# Altium schematic sheet HighPassFilter.SchDoc(HighPassFilter)
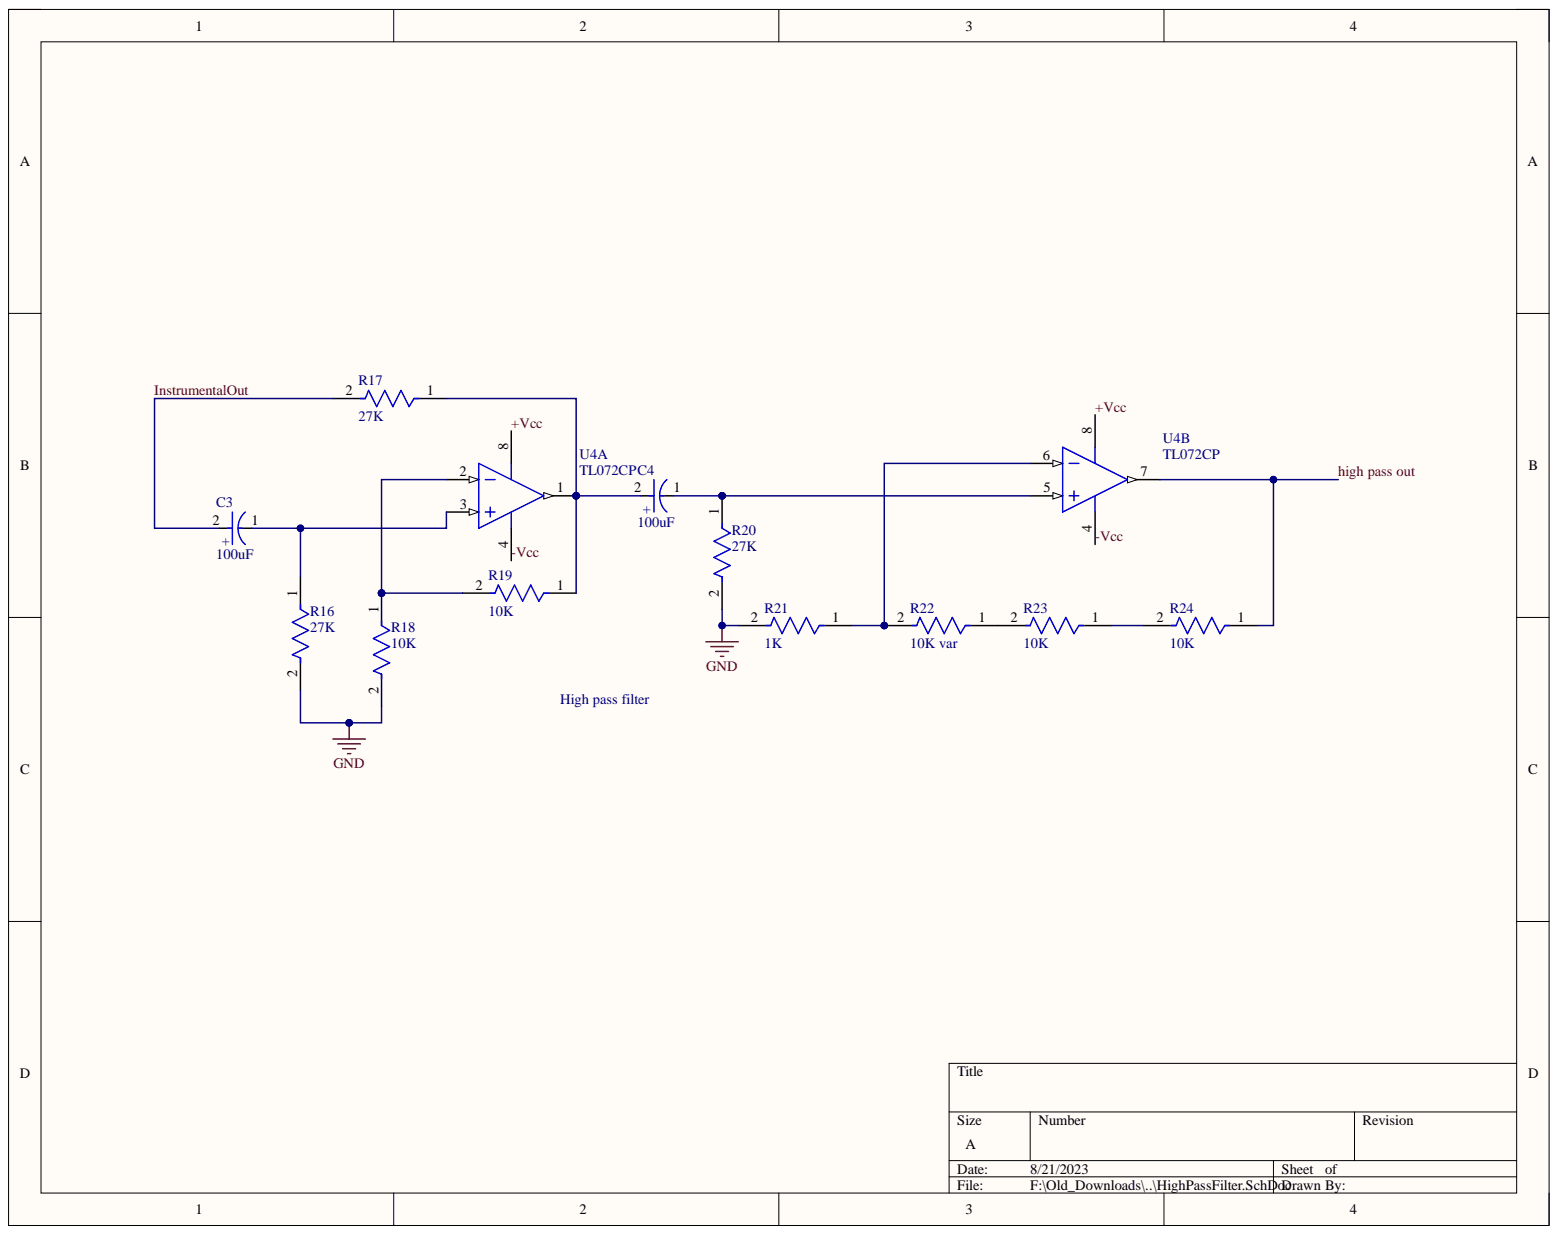
[diagram p0: HighPassFilter.SchDoc]

## Parts
C3  100uF  [FP-RAD-TH-D_6_3_0_5-L_11-MFG]
C4  100uF  [FP-RAD-TH-D_6_3_0_5-L_11-MFG]
R16  27K  [FP-CFR-25-MFG]
R17  27K  [FP-CFR-25-MFG]
R18  10K  [FP-CFR-25-MFG]
R19  10K  [FP-CFR-25-MFG]
R20  27K  [FP-CFR-25-MFG]
R21  1K  [FP-CFR-25-MFG]
R22  10K var  [FP-CFR-25-MFG]
R23  10K  [FP-CFR-25-MFG]
R24  10K  [FP-CFR-25-MFG]
U4  TL072CP  [P0008A]  (parts A,B)

## Nets
GND: R16.2 R18.2 R20.2 R21.2
+Vcc: U4.8
-Vcc: U4.4
high pass out: R24.1 U4.7
InstrumentalOut: C3.2 R17.2
NetC3_1: C3.1 R16.1 U4.3
NetC4_1: C4.1 R20.1 U4.5
NetC4_2: C4.2 R17.1 R19.1 U4.1
NetR18_1: R18.1 R19.2 U4.2
NetR21_1: R21.1 R22.2 U4.6
NetR22_1: R22.1 R23.2
NetR23_1: R23.1 R24.2

## Library data of the parts
C3 C4 = CMP-05427-000237-1: Aluminum Electrolytic Capacitors (Radial Lead Type) 4.7uF ±20% 160V; Dielectric Material: Aluminium; Dissipation Factor: 0.15%; Lead Diameter: 0.5mm; Lead/Base Style: Straight; Life (Hours): 2000Hour; Ripple Current: 43mA; Capacitance: 4.7uF; Case/Package: Radial; Component Kind: Standard; Construction: RADIAL; Diameter: 6.3mm; Height: 11.2mm; Height - Seated (Max): 0.48inch; Lead Free: Lead Free; Lead Pitch: 2.5mm; Length: 11.2mm; Library Name: Altium Content Vault; Max Operating Temperature: 105°C; Min Operating Temperature: -25°C; Mount: Through Hole; Number of Pins: 2; Package Quantity: 200; Packaging: Bulk; Radiation Hardening: No; REACH SVHC: No SVHC; Ripple Current (AC): 43mA; RoHS Compliant: Yes; Series: NHG; Termination: Radial; Tolerance: 20%; Voltage: 160V; Voltage Rating: 160V; Voltage Rating (DC): 160V; Weight: 0.01lb; Width: 0.25inch
R16-R24 = CMP-03413-000009-1: RES 10 OHM 1/4W 5% AXIAL; Max Power Dissipation: 250mW; Resistance: 10R; Temperature Coefficient: 500ppm/°C; Case/Package: Axial; Component Kind: Standard; Length: 0.248inch; Library Name: Altium Content Vault; Max Operating Temperature: 155°C; Min Operating Temperature: -55°C; Number of Terminations: 2; Package Quantity: 200; Packaging: Bulk; Resistor Type: Carbon Film; RoHS Compliant: Yes; Series: Carbon Film; Tolerance: 5%
U4 = CMP-0017-00950-2: Dual Low-Noise JFET-Input General-Purpose Operational Amplifier, 7 to 36 V, 0 to 70 degC, 8-pin DIP (P8), Pb-Free (RoHS); Manufacturer: Texas Instruments; CMRR(Min)(dB): 70; GBW(Typ)(MHz): 3; IIB(Max)(pA): 200; Iq per channel(Max)(mA): 2.5; Number of Channels: 2; Offset Drift(Typ)(uV/C): 18; Rating: Catalog; Slew Rate(Typ)(V/us): 13; Sub Family: General Purpose Amplifier, High Supply Voltage Amplifier (>=30V); Total Supply Voltage(Max)(+5V is 5, +/-5V is 10): 36; Total Supply Voltage(Min)(+5V is 5, +/-5V is 10): 7; Vio (25C)(Max)(mV): 10; Code_JEDEC: MS-001; Component Kind: Standard; ComponentLink1Description: Manufacturer URL; ComponentLink1URL: http://www.ti.com/; ComponentLink2Description: Datasheet; ComponentLink2URL: http://www.ti.com/lit/ds/symlink/tl072.pdf; ComponentLink3Description: Package Specification; ComponentLink3URL: http://www.ti.com/litv/pdf/mpdi001b; DatasheetVersion: SLOS080J; Eco-Plan: Pb-Free (RoHS); Library Name: Altium Content Vault; Mounting Technology: Through Hole; PackageDescription: 8-Pin Plastic In-Line, Body 9.59 x 6.35 mm, Pitch 2.54 mm; PackageReference: P0008A; PackageVersion: 4040082/E, 04/2010; PartNumber: TL072CP; Vn at 1kHz(Typ)(nV/rtHz): 18
